# Altium schematic sheet Astable_Sheet.SchDoc
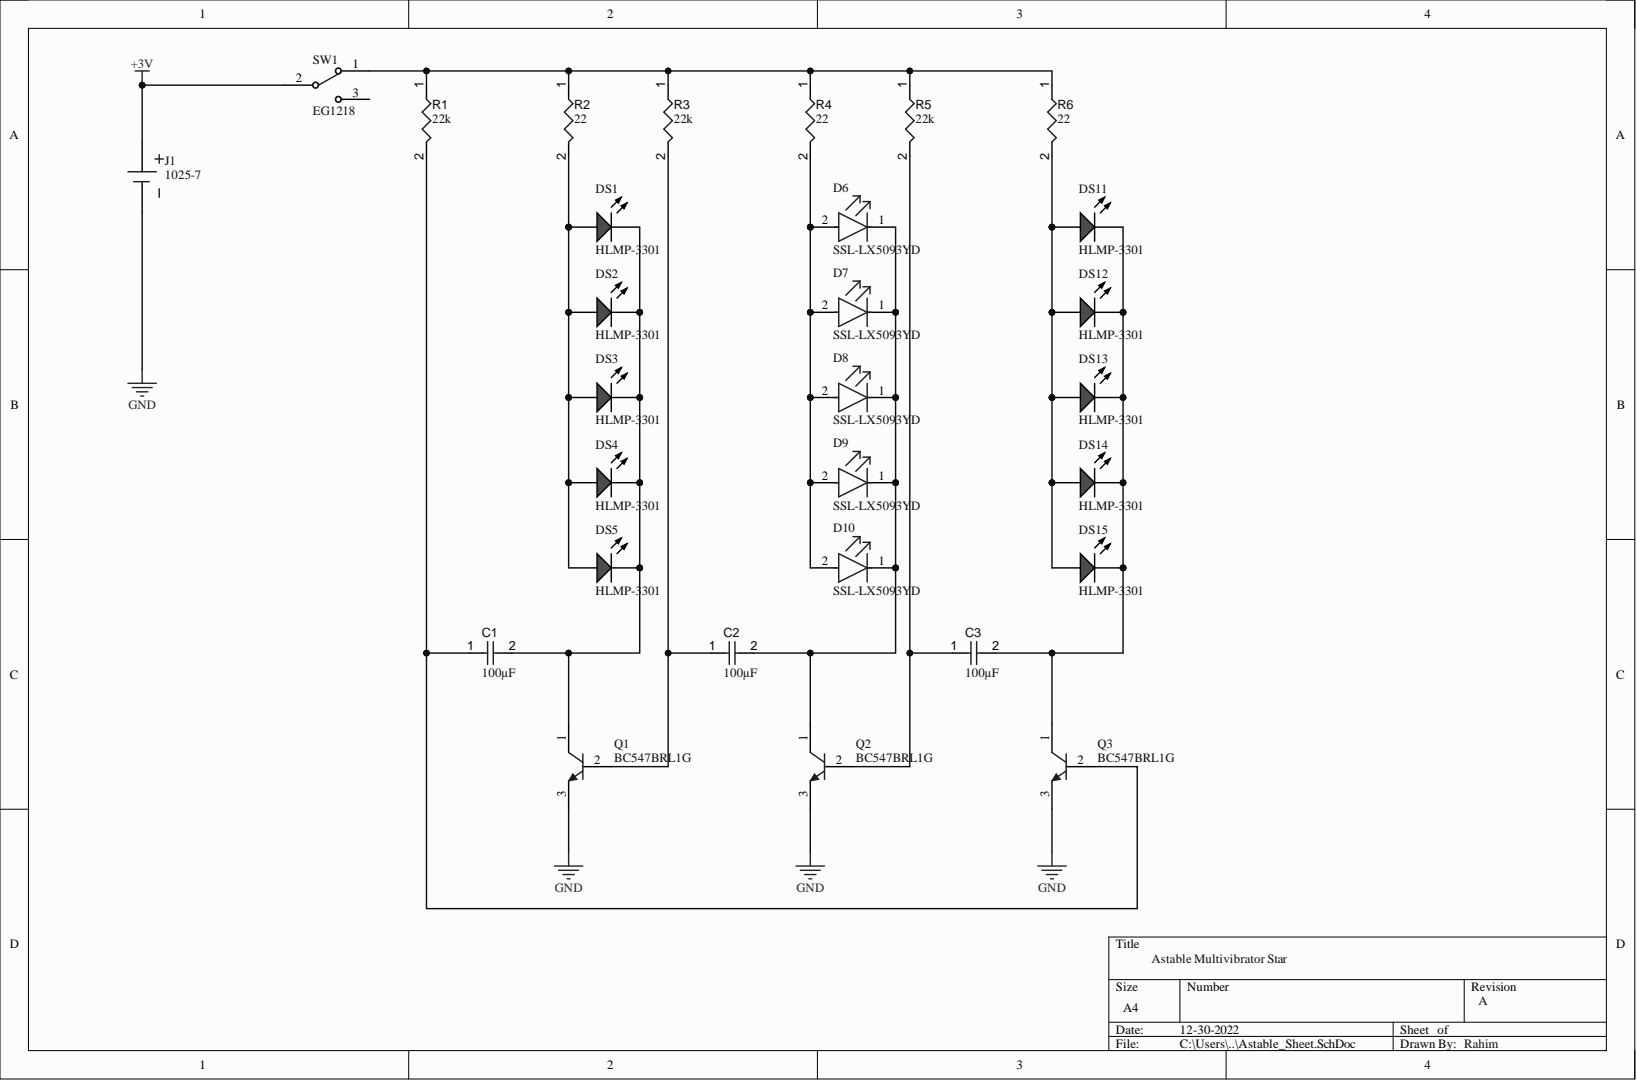
[diagram p0: Astable_Sheet.SchDoc]

## Parts
C1  value 100µF  [CAPC0805(2012)145_L]  (hidden comment: Capacitor 100µF +/-20% 6.3V 0805)
C2  value 100µF  [CAPC0805(2012)145_L]  (hidden comment: Capacitor 100µF +/-20% 6.3V 0805)
C3  value 100µF  [CAPC0805(2012)145_L]  (hidden comment: Capacitor 100µF +/-20% 6.3V 0805)
D6  SSL-LX5093YD  [FP-SSL-LX5093YD-MFG]
D7  SSL-LX5093YD  [FP-SSL-LX5093YD-MFG]
D8  SSL-LX5093YD  [FP-SSL-LX5093YD-MFG]
D9  SSL-LX5093YD  [FP-SSL-LX5093YD-MFG]
D10  SSL-LX5093YD  [FP-SSL-LX5093YD-MFG]
DS1  HLMP-3301  [AVAG-HLMP-3301-2]
DS2  HLMP-3301  [AVAG-HLMP-3301-2]
DS3  HLMP-3301  [AVAG-HLMP-3301-2]
DS4  HLMP-3301  [AVAG-HLMP-3301-2]
DS5  HLMP-3301  [AVAG-HLMP-3301-2]
DS11  HLMP-3301  [AVAG-HLMP-3301-2]
DS12  HLMP-3301  [AVAG-HLMP-3301-2]
DS13  HLMP-3301  [AVAG-HLMP-3301-2]
DS14  HLMP-3301  [AVAG-HLMP-3301-2]
DS15  HLMP-3301  [AVAG-HLMP-3301-2]
J1  1025-7  [KEYSTONE-1025-7-2_V]
Q1  BC547BRL1G  [ONSC-TO-92-3-29-11_AM_BL]
Q2  BC547BRL1G  [ONSC-TO-92-3-29-11_AM_BL]
Q3  BC547BRL1G  [ONSC-TO-92-3-29-11_AM_BL]
R1  value 22k  [RESC0402(1005)_L]  (hidden comment: 22KR2F)
R2  value 22  [RESC1609X50X30ML08T20]  (hidden comment: Resistor 22R +/-1% 0603 100 mW)
R3  value 22k  [RESC0402(1005)_L]  (hidden comment: 22KR2F)
R4  value 22  [RESC1609X50X30ML08T20]  (hidden comment: Resistor 22R +/-1% 0603 100 mW)
R5  value 22k  [RESC0402(1005)_L]  (hidden comment: 22KR2F)
R6  value 22  [RESC1609X50X30ML08T20]  (hidden comment: Resistor 22R +/-1% 0603 100 mW)
SW1  EG1218  [ESWI-EG1218_V]

## Nets
+3V: J1.1 SW1.2
GND: J1.2 Q1.3 Q2.3 Q3.3
NetC1_1: C1.1 Q3.2 R1.2
NetC1_2: C1.2 DS1.1 DS2.1 DS3.1 DS4.1 DS5.1 Q1.1
NetC2_1: C2.1 Q1.2 R3.2
NetC2_2: C2.2 D6.1 D7.1 D8.1 D9.1 D10.1 Q2.1
NetC3_1: C3.1 Q2.2 R5.2
NetC3_2: C3.2 DS11.1 DS12.1 DS13.1 DS14.1 DS15.1 Q3.1
NetD6_2: D6.2 D7.2 D8.2 D9.2 D10.2 R4.2
NetDS1_2: DS1.2 DS2.2 DS3.2 DS4.2 DS5.2 R2.2
NetDS11_2: DS11.2 DS12.2 DS13.2 DS14.2 DS15.2 R6.2
NetR1_1: R1.1 R2.1 R3.1 R4.1 R5.1 R6.1 SW1.1

Not connected: SW1 3

## Library data of the parts
C1-C3 = CMP-001-00054-4: Chip Capacitor, 100µF +/-20%, 6.3V, 0805, Thickness 1.45 mm; Tolerance: 10%; Case/Package: 0805; Component Kind: Standard; Library Name: McMaster University Workspace; Max Operating Temperature: 85°C; Min Operating Temperature: -55°C; Mounting Technology: SMT; Pins: 2; Voltage Rating: 6.3V
D6-D10 = CMP-57192-000007-1: LED YELLOW DIFFUSED T-1 3/4 T/H; Dominant Wavelength: 568nm; Forward Current: 20mA; Forward Voltage: 2.1V; Lens Style: Dome; Luminous Intensity: 30mcd; Number of LEDs: 1; Viewing Angle: 60°; Wavelength: 585nm; Case/Package: Radial; Color: Yellow; Component Kind: Standard; Diameter: 5mm; Height: 8.6mm; Illumination Color: Yellow; Lead Free: Lead Free; Length: 0.5inch; Lens Color: Yellow; Lens Transparency: Diffused; Library Name: Altium Content Vault; Max Operating Temperature: 85°C; Min Operating Temperature: -40°C; Mount: Through Hole; Number of Pins: 2; Package Quantity: 1000; Packaging: Bulk; REACH SVHC: No SVHC; RoHS Compliant: Yes; Series: -; Test Current: 20mA; Weight: 0.01lb; Width: 1.5inch
DS1-DS5 DS11-DS15 = CMP-2000-06411-1: T-1 3/4 (5 mm) Diffused LED Lamp, 1.9 V, -40 to 100 degC, 2-Pin THD, RoHS, Bulk; Manufacturer: Avago; Chip Material: GaP; DELETED: Compliant; Dominant Wave Length: 626nm; Emitting Color: Red; Forward Current: 25mA; Forward Voltage: 2.4V; High Brightness: No; Lens Type: ColoredDiffused; Lum Intensity: 5.4mcd; Main Category: StandardLED; Number of  Elements: 1; Peak Wavelength: 635nm; Power Dissipation: 85W; Product Depth (mm): 6.1mm; Product Height (mm): 9.19mm; Reverse Voltage: 5V; Shape Type: Circular; Test Current (It): 10mA; Type: Uni-Color; Viewing Angle: 60deg; Component Kind: Standard; Datasheet URL: http://www.avagotech.com/docs/AV02-1558EN; Datasheet Version: 03/2015; Lens Dimensions: 5.08x5.08x8.3mm; Library Name: Altium Content Vault; Luminous Intensity: 5.4mcd; Manufacturer URL: http://www.avagotech.com/; Mounting: ThroughHole; Mounting Technology: ThroughHole; Operating Temp Range: -40Cto100C; Operating Temperature Classification: Industrial; Package Description: 2-Pin Through Hole LED, Dia 5.85 mm; Package Reference: HLMP-3301; Package Type: T-13/4; Packaging: TapeandReel; Pin Count: 2; Product Length (mm): 6.1mm; Rad Hardened: No
J1 = CMP-0465-00001-2: Holder for 2477 or 2430 Cells P/N 1025-7, Body 27.762 x 30.963 mm, THM; Manufacturer: Keystone; # of Cells: 1; Cell Size: 24 mm; Component Kind: Standard; ComponentLink1Description: Manufacturer URL; ComponentLink1URL: http://www.keyelco.com/; ComponentLink2Description: Datasheet; ComponentLink2URL: http://www.keyelco.com/product-pdf.cfm?p=3950; DatasheetVersion: Rev.C; Library Name: Altium Content Vault; Material: Plastic; Mounting Technology: Through Hole; PackageDescription: 2-Pin Hand Drawn, Body 27.762 x 30.963 mm; PackageReference: KEYSTONE-1025-7-2; PartNumber: 1025-7; Switch Current Limit (Typ) (A): 1; Type: HOLDER
Q1-Q3 = CMP-1048-01605-1: Amplifier Transistor, NPN Silicon, 3-Pin TO-92, Pb-Free, Tape and Reel; Manufacturer: On Semiconductor; fT Min (MHz): 150; IC Continuous (A): 0.1; Component Kind: Standard; ComponentLink1Description: Manufacturer URL; ComponentLink1URL: http://www.onsemi.com/; ComponentLink2Description: Datasheet; ComponentLink2URL: http://www.onsemi.com/pub_link/Collateral/BC546-D.PDF; ComponentLink3Description: Package Specification; ComponentLink3URL: http://www.onsemi.com/pub_link/Collateral/MAC97-D.PDF; DatasheetVersion: Rev. 7; Library Name: Altium Content Vault; Mounting Technology: Through Hole; PackageDescription: 3-Pin Transistor Outline, Body 4.825 x 3.685 mm, Pitch 1.27 mm; PackageReference: TO-92-3-29-11_AM_BL; PackageVersion: Rev. AM; Packing: Tape and Reel; PartNumber: BC547BRL1G; Polarity: NPN; RoHS: TRUE
R1 R3 R5 = CMP-009-00081-2: 22K 0.063W 1% 0402 (1005 Metric)  SMD; Power: 63mW; Case/Package: 0402; Component Kind: Standard; Library Name: McMaster University Workspace; Mounting Technology: SMT; Pins: 2; Tolerance: 1%
R2 R4 R6 = CMP-009-00027-5: Chip Resistor, 22 Ohm, +/- 1%, 100 mW, -55 to 155 degC, 0603 (1608 Metric); Power: 100mW; Voltage Rating: 75V; Case/Package: 0603; Component Kind: Standard; Library Name: McMaster University Workspace; Max Operating Temperature: 155°C; Min Operating Temperature: -55°C; Mounting Technology: SM; Pins: 2; RoHS Compliant: True; Tolerance: 1%
SW1 = CMP-011-00006-2: Slide Switch, SPDT, 500 V, -20 to 70 degC, 3-Pin THD, RoHS, Bulk; Manufacturer: E-Switch; Contact Current Rating: 200mA; Operating Force: 250gf; Voltage Rating (AC): 30V; Case/Package: EG1218; Component Kind: Standard; Contact Finish: Silver; Contact Material: Copper; Contact Plating: Silver; Current Rating: 200mA; Datasheet URL: https://www.e-switch.com/system/asset/product_line/data_sheet/119/EG.pdf; Datasheet Version: Oct-15; Library Name: McMaster University Workspace; Manufacturer URL: http://www.e-switch.ch/en/; Max Operating Temperature: 70°C; Min Operating Temperature: -20°C; Mounting Technology: TH; Package Description: 3-Pin Through Hole Device, Body 11.6 x 4 mm, Pitch 2.5 mm; Package Quantity: 1000; Package Reference: EG1218; Packaging: Bulk; Pins: 3; RoHS Compliant: True; Throw Configuration: SPDT; Voltage Rating (DC): 30V
